# Altium schematic sheet A5-BAT_CONN.SchDoc
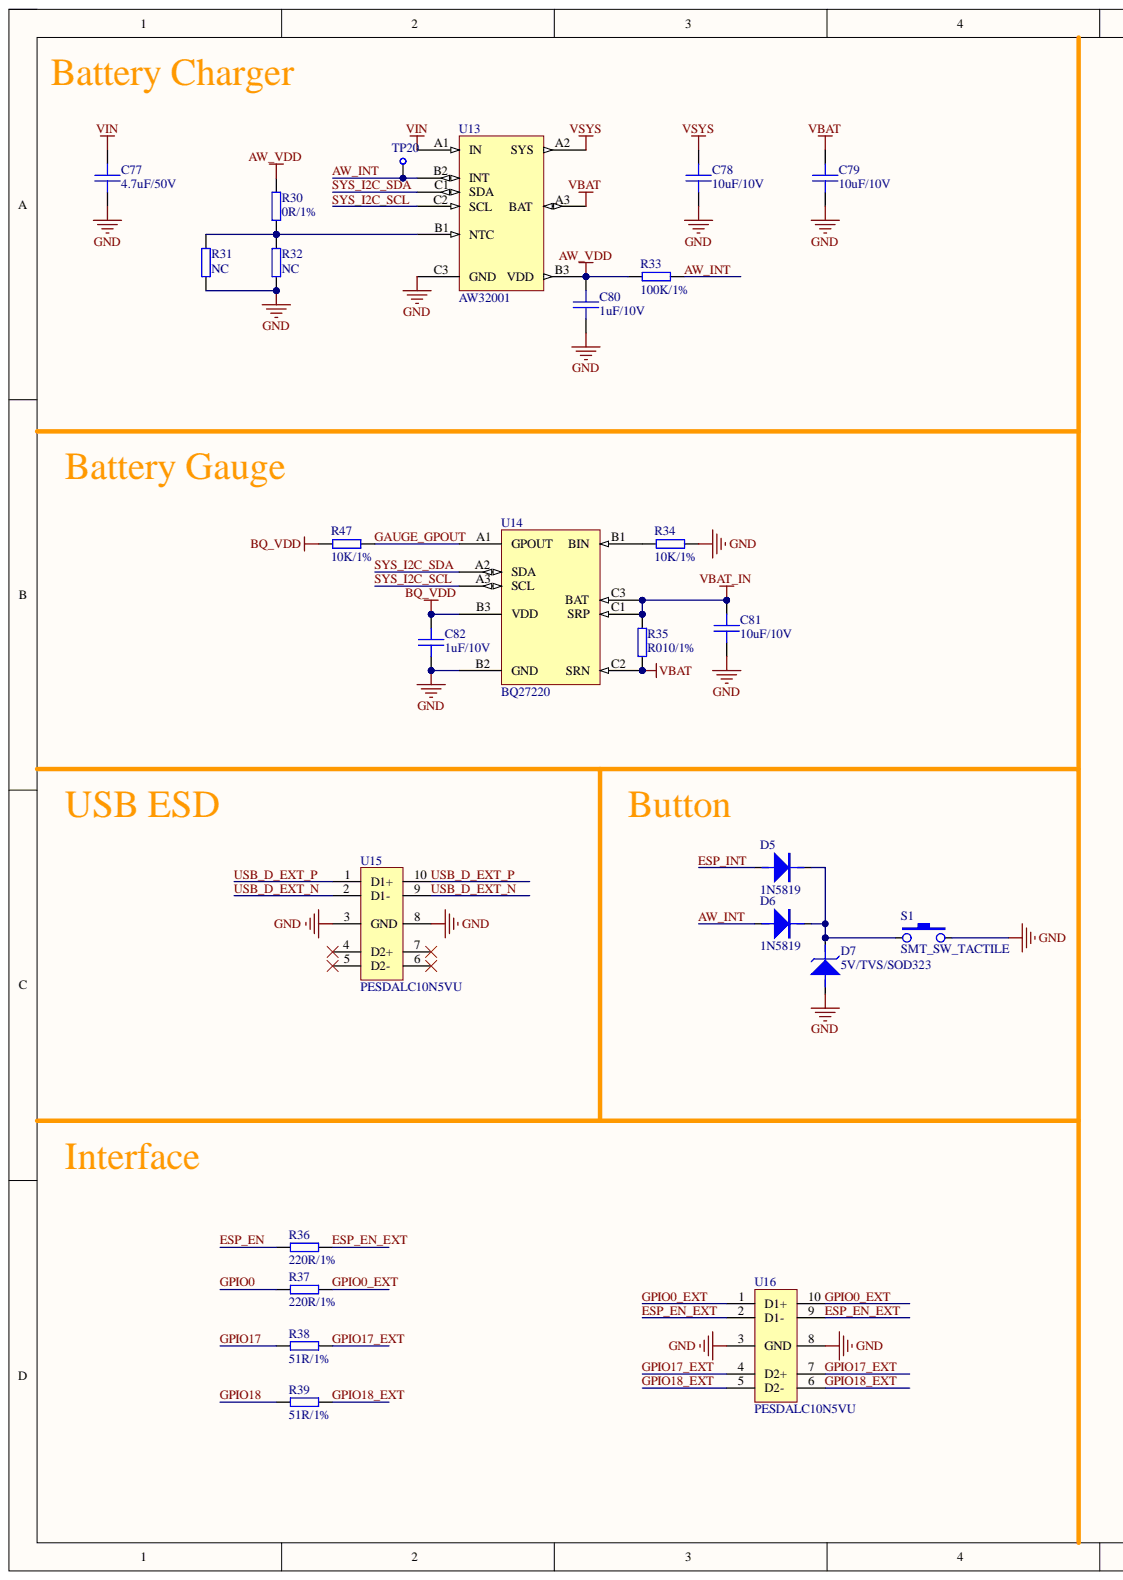
[diagram p0: A5-BAT_CONN.SchDoc, left]
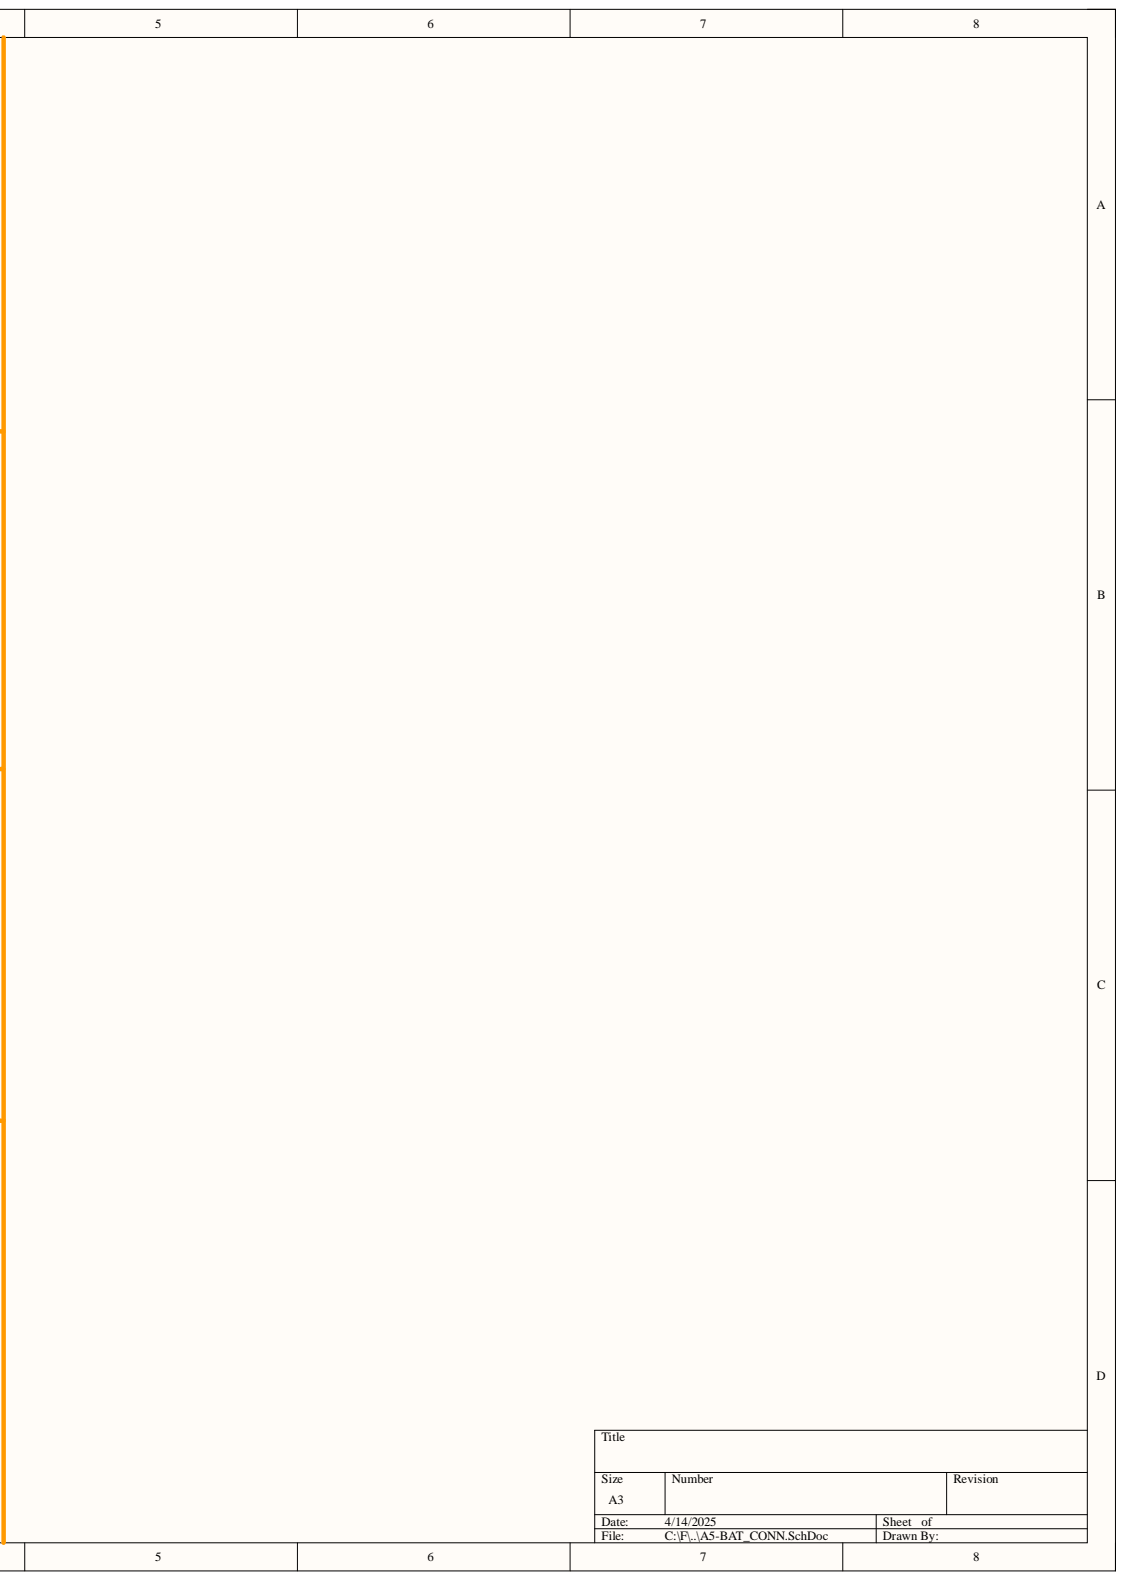
[diagram p1: A5-BAT_CONN.SchDoc, right]

## Parts
C77  4.7uF/50V  [C0603-HD]
C78  10uF/10V  [C0402-L-HD]
C79  10uF/10V  [C0402-L-HD]
C80  1uF/10V  [C0201-HD]
C81  10uF/10V  [C0402-L-HD]
C82  1uF/10V  [C0201-HD]
D5  1N5819  [SMT_DIODE_SOD_523]
D6  1N5819  [SMT_DIODE_SOD_523]
D7  5V/TVS/SOD323  [SOD-323FL-HD]
R30  0R/1%  [R0402-HD]
R31  NC  [R0603-HD]
R32  NC  [R0402-HD]
R33  100K/1%  [R0402-HD]
R34  10K/1%  [R0402-HD]
R35  R010/1%  [R0603-HD]
R36  220R/1%  [R0402-HD]
R37  220R/1%  [R0402-HD]
R38  51R/1%  [R0402-HD]
R39  51R/1%  [R0402-HD]
R47  10K/1%  [R0402-HD]
S1  SMT_SW_TACTILE  [SMT_SW_TSA343G00-250J2]
TP20  SMT_TEST_POINT  [SMT_TEST_POINT]  (value not drawn)
U13  AW32001  [BGA9C50P3X3_168X168X67]
U14  BQ27220  [BGA9C50P3X3_158X162X62]
U15  PESDALC10N5VU  [R-PSON-N10]
U16  PESDALC10N5VU  [R-PSON-N10]

## Nets
AW_VDD: C80.1 R30.2 R33.2 U13.B3(VDD)
BQ_VDD: C82.1 R47.1 U14.B3(VDD)
GND: C77.1 C78.1 C79.1 C80.2 C81.1 C82.2 D7.1 R31.1 R32.1 R34.2 S1.2 U13.C3(GND) U14.B2(GND) U15.3 U15.8(GND) U16.3 U16.8(GND)
VBAT: C79.2 R35.1 U13.A3(BAT) U14.C2(SRN)
VBAT_IN: C81.2 R35.2 U14.C1(SRP) U14.C3(BAT)
VIN: C77.2 U13.A1(IN)
VSYS: C78.2 U13.A2(SYS)
AW_INT: D6.1 R33.1 TP20.1 U13.B2(INT)
ESP_EN: R36.2
ESP_EN_EXT: R36.1 U16.2(D1-) U16.9
ESP_INT: D5.1
GAUGE_GPOUT: R47.2 U14.A1(GPOUT)
GPIO0: R37.2
GPIO0_EXT: R37.1 U16.1 U16.10(D1+)
GPIO17: R38.2
GPIO17_EXT: R38.1 U16.4 U16.7(D2+)
GPIO18: R39.2
GPIO18_EXT: R39.1 U16.5(D2-) U16.6
SYS_I2C_SCL: U13.C2(SCL) U14.A3(SCL)
SYS_I2C_SDA: U13.C1(SDA) U14.A2(SDA)
USB_D_EXT_N: U15.2(D1-) U15.9
USB_D_EXT_P: U15.1 U15.10(D1+)
NetD5_2: D5.2 D6.2 D7.2 S1.1
NetR30_1: R30.1 R31.2 R32.2 U13.B1(NTC)
NetR34_1: R34.1 U14.B1(BIN)

Not connected: U15 4 5(D2-) 6 7(D2+)

## Library data of the parts
C77-C82 = SMT_C_0402: Surface mount capacitor 0402; Component Kind: Standard; Library Name: Vowstar_Basic_Device.SchLib
D5 D6 = SMT_DIODE_SOD_523: Surface mount diode SOD-523(0603) Package; Component Kind: Standard; Library Name: Vowstar_Basic_Device.SchLib
D7 = SMT_DIODE_TVS_U_SOD_523: Surface mount unidirectional TVS diode SOD-523(0603) Package; Component Kind: Standard; Library Name: Vowstar_Basic_Device.SchLib
R30-R39 R47 = SMT_R_0402: Surface mount resistor 0402; Component Kind: Standard; Library Name: Vowstar_Basic_Device.SchLib
S1 = SMT_SW_TACTILE: Generic SMT Tactile Switch; Component Kind: Standard; Library Name: Vowstar_Switch.SchLib
TP20 = SMT_TEST_POINT: SMT Test Point; Component Kind: Standard; Library Name: Vowstar_Basic_Device.SchLib
U15 U16 = TPD4E05U06: Component Kind: Standard; Library Name: GENERAL-IC.SchLib
U13 = AW32001: Component Kind: Standard; Library Name: GENERAL-IC.SchLib
U14 = BQ27220: Component Kind: Standard; Library Name: GENERAL-IC.SchLib
